# Altium schematic sheet Sheet2.SchDoc(Sheet2)
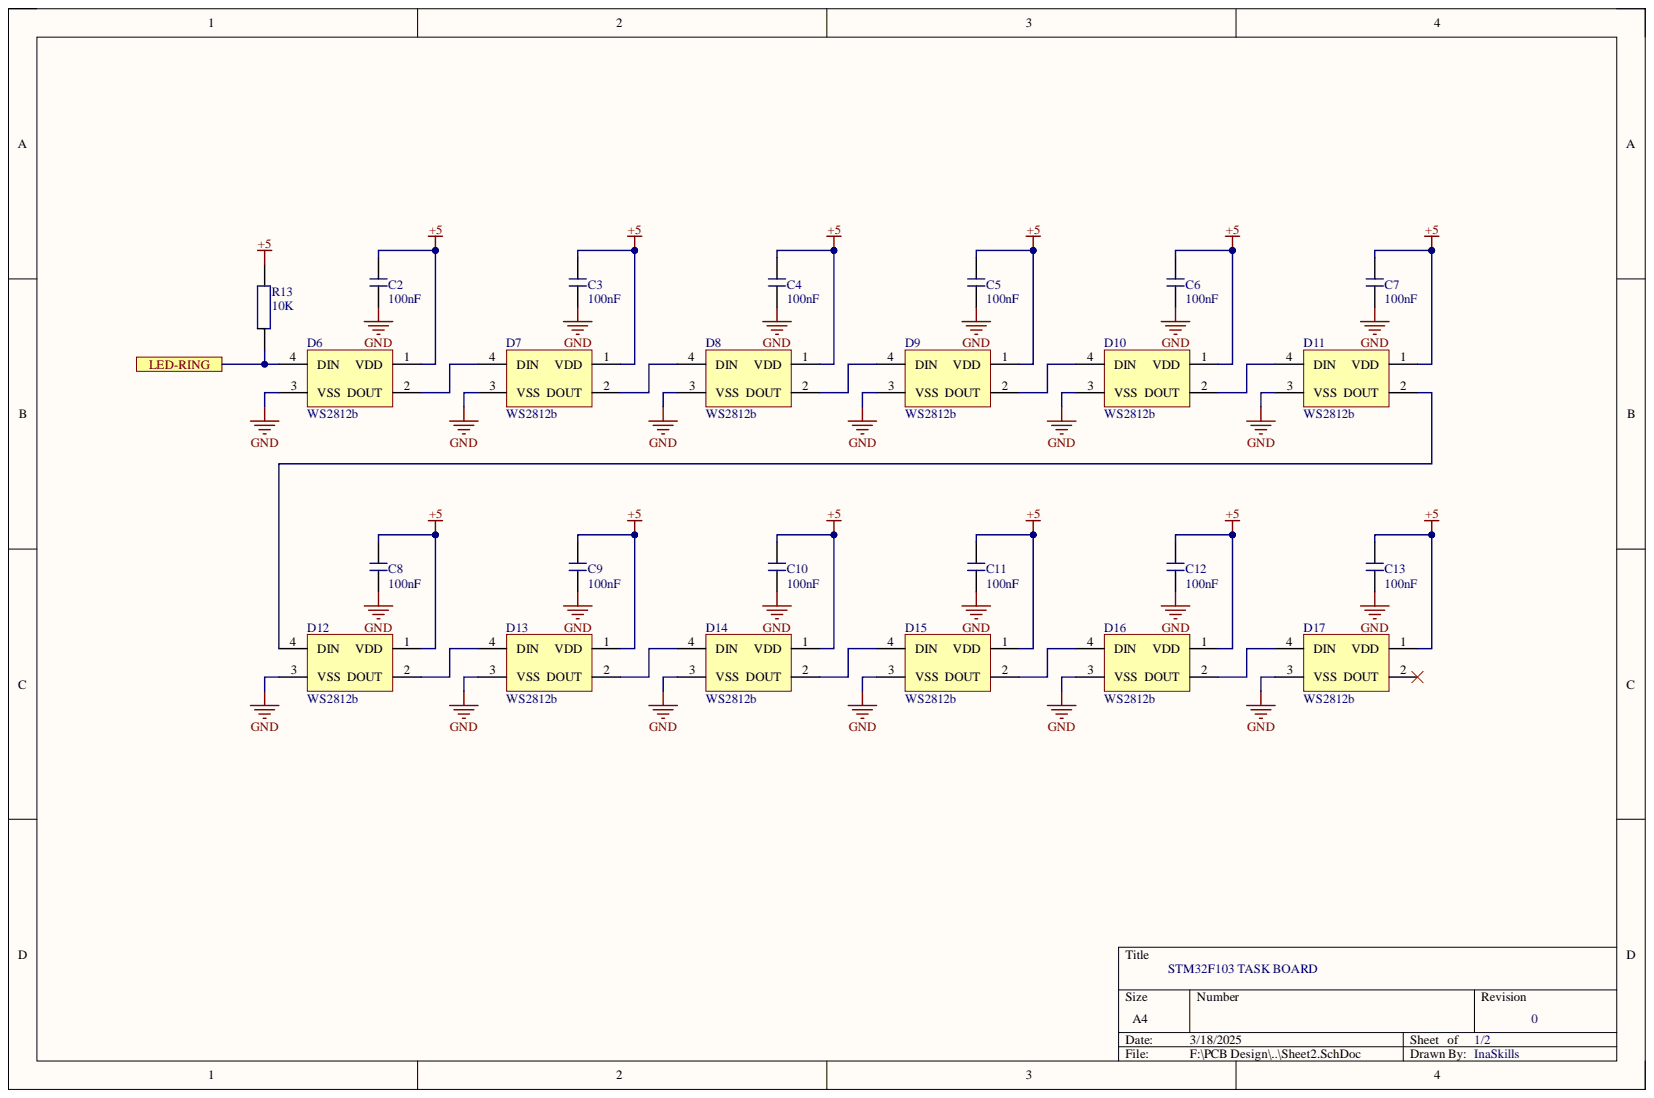
[diagram p0: Sheet2.SchDoc]

## Parts
C2  value 100nF  [0805]  (hidden comment: Capasitor Non Polar)
C3  value 100nF  [0805]  (hidden comment: Capasitor Non Polar)
C4  value 100nF  [0805]  (hidden comment: Capasitor Non Polar)
C5  value 100nF  [0805]  (hidden comment: Capasitor Non Polar)
C6  value 100nF  [0805]  (hidden comment: Capasitor Non Polar)
C7  value 100nF  [0805]  (hidden comment: Capasitor Non Polar)
C8  value 100nF  [0805]  (hidden comment: Capasitor Non Polar)
C9  value 100nF  [0805]  (hidden comment: Capasitor Non Polar)
C10  value 100nF  [0805]  (hidden comment: Capasitor Non Polar)
C11  value 100nF  [0805]  (hidden comment: Capasitor Non Polar)
C12  value 100nF  [0805]  (hidden comment: Capasitor Non Polar)
C13  value 100nF  [0805]  (hidden comment: Capasitor Non Polar)
D6  WS2812b  [WS2812B]
D7  WS2812b  [WS2812B]
D8  WS2812b  [WS2812B]
D9  WS2812b  [WS2812B]
D10  WS2812b  [WS2812B]
D11  WS2812b  [WS2812B]
D12  WS2812b  [WS2812B]
D13  WS2812b  [WS2812B]
D14  WS2812b  [WS2812B]
D15  WS2812b  [WS2812B]
D16  WS2812b  [WS2812B]
D17  WS2812b  [WS2812B]
R13  value 10K  [0805]  (hidden comment: Resistor)

## Ports
LED-RING

## Nets
+5: C2.2 C3.2 C4.2 C5.2 C6.2 C7.2 C8.2 C9.2 C10.2 C11.2 C12.2 C13.2 D6.1(VDD) D7.1(VDD) D8.1(VDD) D9.1(VDD) D10.1(VDD) D11.1(VDD) D12.1(VDD) D13.1(VDD) D14.1(VDD) D15.1(VDD) D16.1(VDD) D17.1(VDD) R13.1
GND: C2.1 C3.1 C4.1 C5.1 C6.1 C7.1 C8.1 C9.1 C10.1 C11.1 C12.1 C13.1 D6.3(VSS) D7.3(VSS) D8.3(VSS) D9.3(VSS) D10.3(VSS) D11.3(VSS) D12.3(VSS) D13.3(VSS) D14.3(VSS) D15.3(VSS) D16.3(VSS) D17.3(VSS)
LED-RING: D6.4(DIN) R13.2 ; port LED-RING
NetD6_2: D6.2(DOUT) D7.4(DIN)
NetD7_2: D7.2(DOUT) D8.4(DIN)
NetD8_2: D8.2(DOUT) D9.4(DIN)
NetD9_2: D9.2(DOUT) D10.4(DIN)
NetD10_2: D10.2(DOUT) D11.4(DIN)
NetD11_2: D11.2(DOUT) D12.4(DIN)
NetD12_2: D12.2(DOUT) D13.4(DIN)
NetD13_2: D13.2(DOUT) D14.4(DIN)
NetD14_2: D14.2(DOUT) D15.4(DIN)
NetD15_2: D15.2(DOUT) D16.4(DIN)
NetD16_2: D16.2(DOUT) D17.4(DIN)

Not connected: D17 2(DOUT)

## Library data of the parts
C2-C13 = Capasitor Non Polar: Component Kind: Standard; Library Name: faridLib.IntLib
D6-D17 = WS2812b: Component Kind: Standard; Value: WS2812b; Library Name: faridLib.IntLib
R13 = Resistor: Component Kind: Standard; Library Name: faridLib.IntLib
